# Altium schematic sheet RF_AD9361.SchDoc
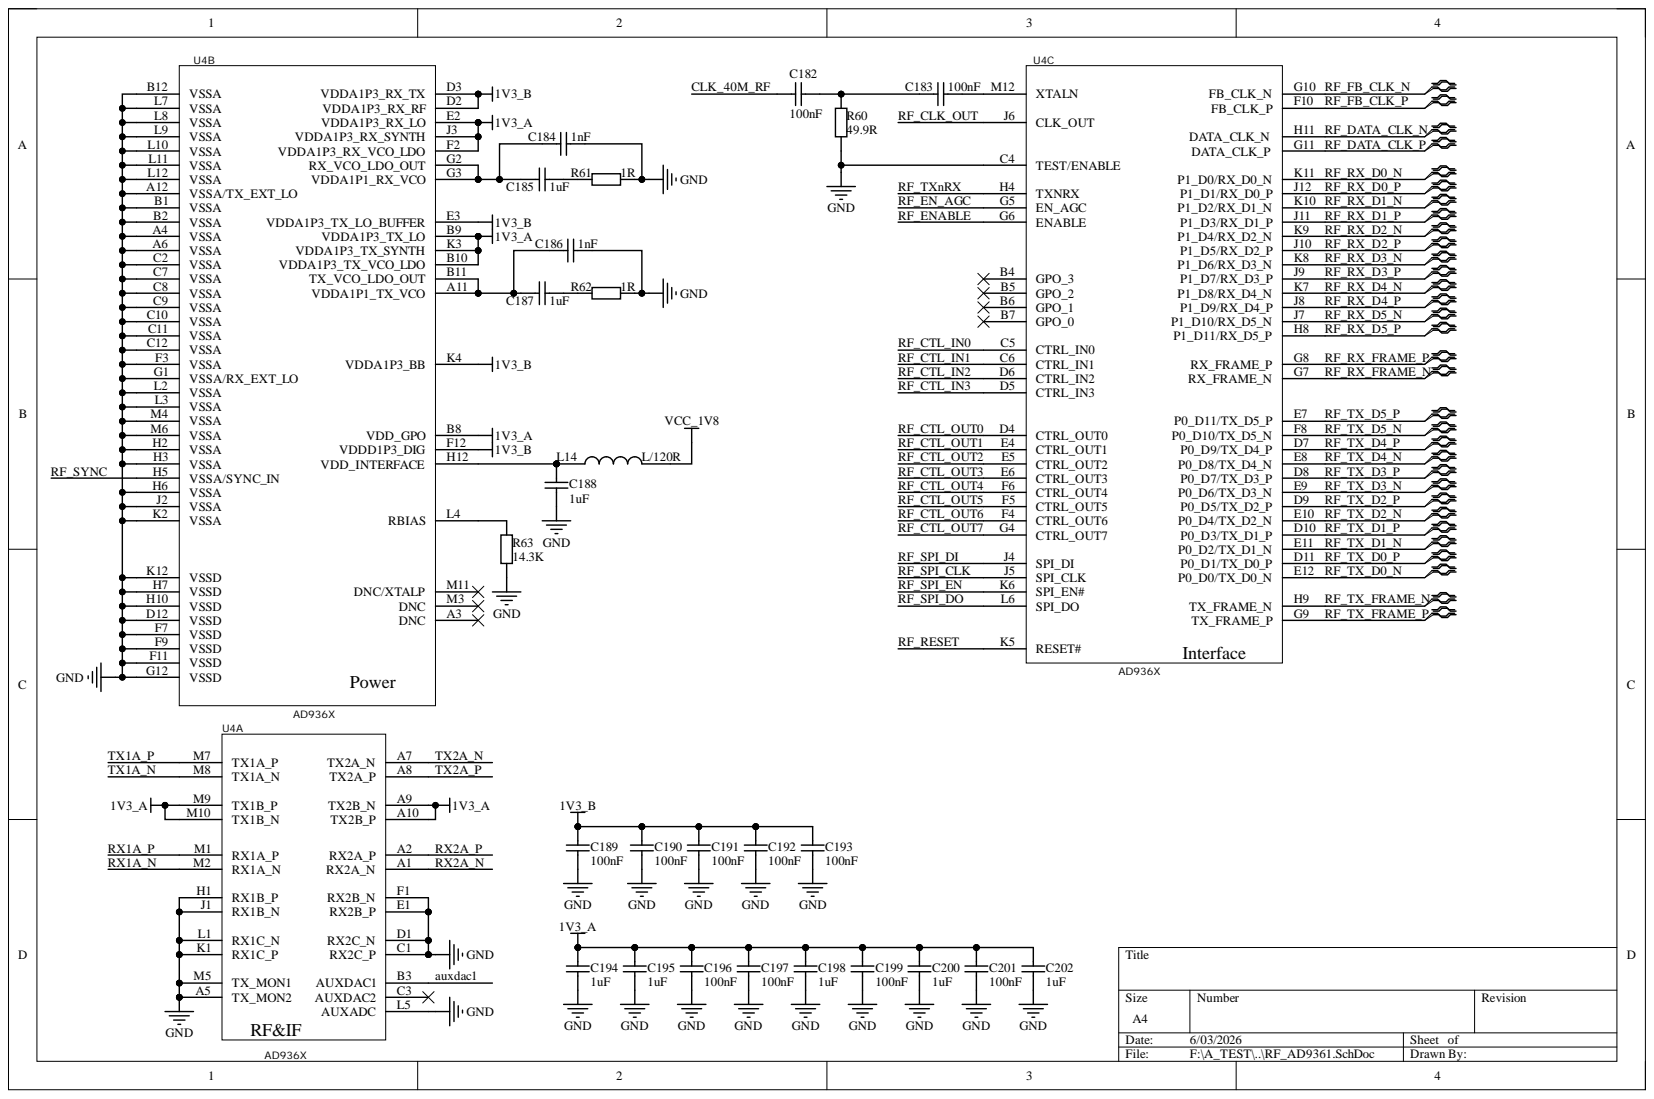
[diagram p0: RF_AD9361.SchDoc]

## Parts
C182  100nF  [C 0402_L]
C183  100nF  [C 0402_L]
C184  1nF  [C 0402_L]
C185  1uF  [C 0402_L]
C186  1nF  [C 0402_L]
C187  1uF  [C 0402_L]
C188  1uF  [C 0402_L]
C189  100nF  [C 0402_L]
C190  100nF  [C 0402_L]
C191  100nF  [C 0402_L]
C192  100nF  [C 0402_L]
C193  100nF  [C 0402_L]
C194  1uF  [C 0402_L]
C195  1uF  [C 0402_L]
C196  100nF  [C 0402_L]
C197  100nF  [C 0402_L]
C198  1uF  [C 0402_L]
C199  100nF  [C 0402_L]
C200  1uF  [C 0402_L]
C201  100nF  [C 0402_L]
C202  1uF  [C 0402_L]
L14  L/120R  [L 0402]
R60  49.9R  [R 0402_L]
R61  1R  [R 0402_L]
R62  1R  [R 0402_L]
R63  14.3K  [R 0402_L]
U4  AD936X  [BGA144C80P12X12_1000X1000X170]  (parts A,B,C)

## Nets
1V3_A: C194.2 C195.2 C196.2 C197.2 C198.2 C199.2 C200.2 C201.2 C202.2 U4.A9(TX2B_N) U4.A10(TX2B_P) U4.B8(VDD_GPO) U4.B9(VDDA1P3_TX_LO) U4.B10(VDDA1P3_TX_VCO_LDO) U4.E2(VDDA1P3_RX_LO) U4.F2(VDDA1P3_RX_VCO_LDO) U4.J3(VDDA1P3_RX_SYNTH) U4.K3(VDDA1P3_TX_SYNTH) U4.M9(TX1B_P) U4.M10(TX1B_N)
1V3_B: C189.2 C190.2 C191.2 C192.2 C193.2 U4.D2(VDDA1P3_RX_RF) U4.D3(VDDA1P3_RX_TX) U4.E3(VDDA1P3_TX_LO_BUFFER) U4.F12(VDDD1P3_DIG) U4.K4(VDDA1P3_BB)
GND: C184.2 C186.2 C188.1 C189.1 C190.1 C191.1 C192.1 C193.1 C194.1 C195.1 C196.1 C197.1 C198.1 C199.1 C200.1 C201.1 C202.1 R60.1 R61.2 R62.2 R63.1 U4.A4(VSSA) U4.A5(TX_MON2) U4.A6(VSSA) U4.A12(VSSA/TX_EXT_LO) U4.B1(VSSA) U4.B2(VSSA) U4.B12(VSSA) U4.C1(RX2C_P) U4.C2(VSSA) U4.C4(TEST/ENABLE) U4.C7(VSSA) U4.C8(VSSA) U4.C9(VSSA) U4.C10(VSSA) U4.C11(VSSA) U4.C12(VSSA) U4.D1(RX2C_N) U4.D12(VSSD) U4.E1(RX2B_P) U4.F1(RX2B_N) U4.F3(VSSA) U4.F7(VSSD) U4.F9(VSSD) U4.F11(VSSD) U4.G1(VSSA/RX_EXT_LO) U4.G12(VSSD) U4.H1(RX1B_P) U4.H2(VSSA) U4.H3(VSSA) U4.H6(VSSA) U4.H7(VSSD) U4.H10(VSSD) U4.J1(RX1B_N) U4.J2(VSSA) U4.K1(RX1C_P) U4.K2(VSSA) U4.K12(VSSD) U4.L1(RX1C_N) U4.L2(VSSA) U4.L3(VSSA) U4.L5(AUXADC) U4.L7(VSSA) U4.L8(VSSA) U4.L9(VSSA) U4.L10(VSSA) U4.L11(VSSA) U4.L12(VSSA) U4.M4(VSSA) U4.M5(TX_MON1) U4.M6(VSSA)
VCC_1V8: L14.2
auxdac1: U4.B3(AUXDAC1)
CLK_40M_RF: C182.1
RF_CLK_OUT: U4.J6(CLK_OUT)
RF_CTL_IN0: U4.C5(CTRL_IN0)
RF_CTL_IN1: U4.C6(CTRL_IN1)
RF_CTL_IN2: U4.D6(CTRL_IN2)
RF_CTL_IN3: U4.D5(CTRL_IN3)
RF_CTL_OUT0: U4.D4(CTRL_OUT0)
RF_CTL_OUT1: U4.E4(CTRL_OUT1)
RF_CTL_OUT2: U4.E5(CTRL_OUT2)
RF_CTL_OUT3: U4.E6(CTRL_OUT3)
RF_CTL_OUT4: U4.F6(CTRL_OUT4)
RF_CTL_OUT5: U4.F5(CTRL_OUT5)
RF_CTL_OUT6: U4.F4(CTRL_OUT6)
RF_CTL_OUT7: U4.G4(CTRL_OUT7)
RF_DATA_CLK_N: U4.H11(DATA_CLK_N)
RF_DATA_CLK_P: U4.G11(DATA_CLK_P)
RF_EN_AGC: U4.G5(EN_AGC)
RF_ENABLE: U4.G6(ENABLE)
RF_FB_CLK_N: U4.G10(FB_CLK_N)
RF_FB_CLK_P: U4.F10(FB_CLK_P)
RF_RESET: U4.K5(RESET#)
RF_RX_D0_N: U4.K11(P1_D0/RX_D0_N)
RF_RX_D0_P: U4.J12(P1_D1/RX_D0_P)
RF_RX_D1_N: U4.K10(P1_D2/RX_D1_N)
RF_RX_D1_P: U4.J11(P1_D3/RX_D1_P)
RF_RX_D2_N: U4.K9(P1_D4/RX_D2_N)
RF_RX_D2_P: U4.J10(P1_D5/RX_D2_P)
RF_RX_D3_N: U4.K8(P1_D6/RX_D3_N)
RF_RX_D3_P: U4.J9(P1_D7/RX_D3_P)
RF_RX_D4_N: U4.K7(P1_D8/RX_D4_N)
RF_RX_D4_P: U4.J8(P1_D9/RX_D4_P)
RF_RX_D5_N: U4.J7(P1_D10/RX_D5_N)
RF_RX_D5_P: U4.H8(P1_D11/RX_D5_P)
RF_RX_FRAME_N: U4.G7(RX_FRAME_N)
RF_RX_FRAME_P: U4.G8(RX_FRAME_P)
RF_SPI_CLK: U4.J5(SPI_CLK)
RF_SPI_DI: U4.J4(SPI_DI)
RF_SPI_DO: U4.L6(SPI_DO)
RF_SPI_EN: U4.K6(SPI_EN#)
RF_SYNC: U4.H5(VSSA/SYNC_IN)
RF_TX_D0_N: U4.E12(P0_D0/TX_D0_N)
RF_TX_D0_P: U4.D11(P0_D1/TX_D0_P)
RF_TX_D1_N: U4.E11(P0_D2/TX_D1_N)
RF_TX_D1_P: U4.D10(P0_D3/TX_D1_P)
RF_TX_D2_N: U4.E10(P0_D4/TX_D2_N)
RF_TX_D2_P: U4.D9(P0_D5/TX_D2_P)
RF_TX_D3_N: U4.E9(P0_D6/TX_D3_N)
RF_TX_D3_P: U4.D8(P0_D7/TX_D3_P)
RF_TX_D4_N: U4.E8(P0_D8/TX_D4_N)
RF_TX_D4_P: U4.D7(P0_D9/TX_D4_P)
RF_TX_D5_N: U4.F8(P0_D10/TX_D5_N)
RF_TX_D5_P: U4.E7(P0_D11/TX_D5_P)
RF_TX_FRAME_N: U4.H9(TX_FRAME_N)
RF_TX_FRAME_P: U4.G9(TX_FRAME_P)
RF_TXnRX: U4.H4(TXNRX)
RX1A_N: U4.M2(RX1A_N)
RX1A_P: U4.M1(RX1A_P)
RX2A_N: U4.A1(RX2A_N)
RX2A_P: U4.A2(RX2A_P)
TX1A_N: U4.M8(TX1A_N)
TX1A_P: U4.M7(TX1A_P)
TX2A_N: U4.A7(TX2A_N)
TX2A_P: U4.A8(TX2A_P)
NetC182_2: C182.2 C183.1 R60.2
NetC183_2: C183.2 U4.M12(XTALN)
NetC184_1: C184.1 C185.1 U4.G2(RX_VCO_LDO_OUT) U4.G3(VDDA1P1_RX_VCO)
NetC185_2: C185.2 R61.1
NetC186_1: C186.1 C187.1 U4.A11(VDDA1P1_TX_VCO) U4.B11(TX_VCO_LDO_OUT)
NetC187_2: C187.2 R62.1
NetC188_2: C188.2 L14.1 U4.H12(VDD_INTERFACE)
NetR63_2: R63.2 U4.L4(RBIAS)

Not connected: U4 A3(DNC) B4(GPO_3) B5(GPO_2) B6(GPO_1) B7(GPO_0) C3(AUXDAC2) M3(DNC) M11(DNC/XTALP)

## Library data of the parts
C182-C202 = Cap: 贴片电容; Component Kind: Standard; Library Name: A_Schlib.SchLib
  C182 C183 C189-C193 C196 C197 C199 C201: value: 100nF
  C184 C186: value: 1nF
  C185 C187 C188 C194 C195 C198 C200 C202: value: 1uF
L14 = 电感: 包含功率; Component Kind: Standard; Library Name: A_Schlib.SchLib
R60-R63 = Res: 通用; Component Kind: Standard; Library Name: A_Schlib.SchLib
  R60: value: 49.9R
  R61 R62: value: 1R
  R63: value: 14.3K
U4 = AD936X: 射频捷变收发器; Component Kind: Standard; Library Name: A_Schlib.SchLib
